# Altium schematic sheet FPGA_Bank_2_3_I2C.SchDoc
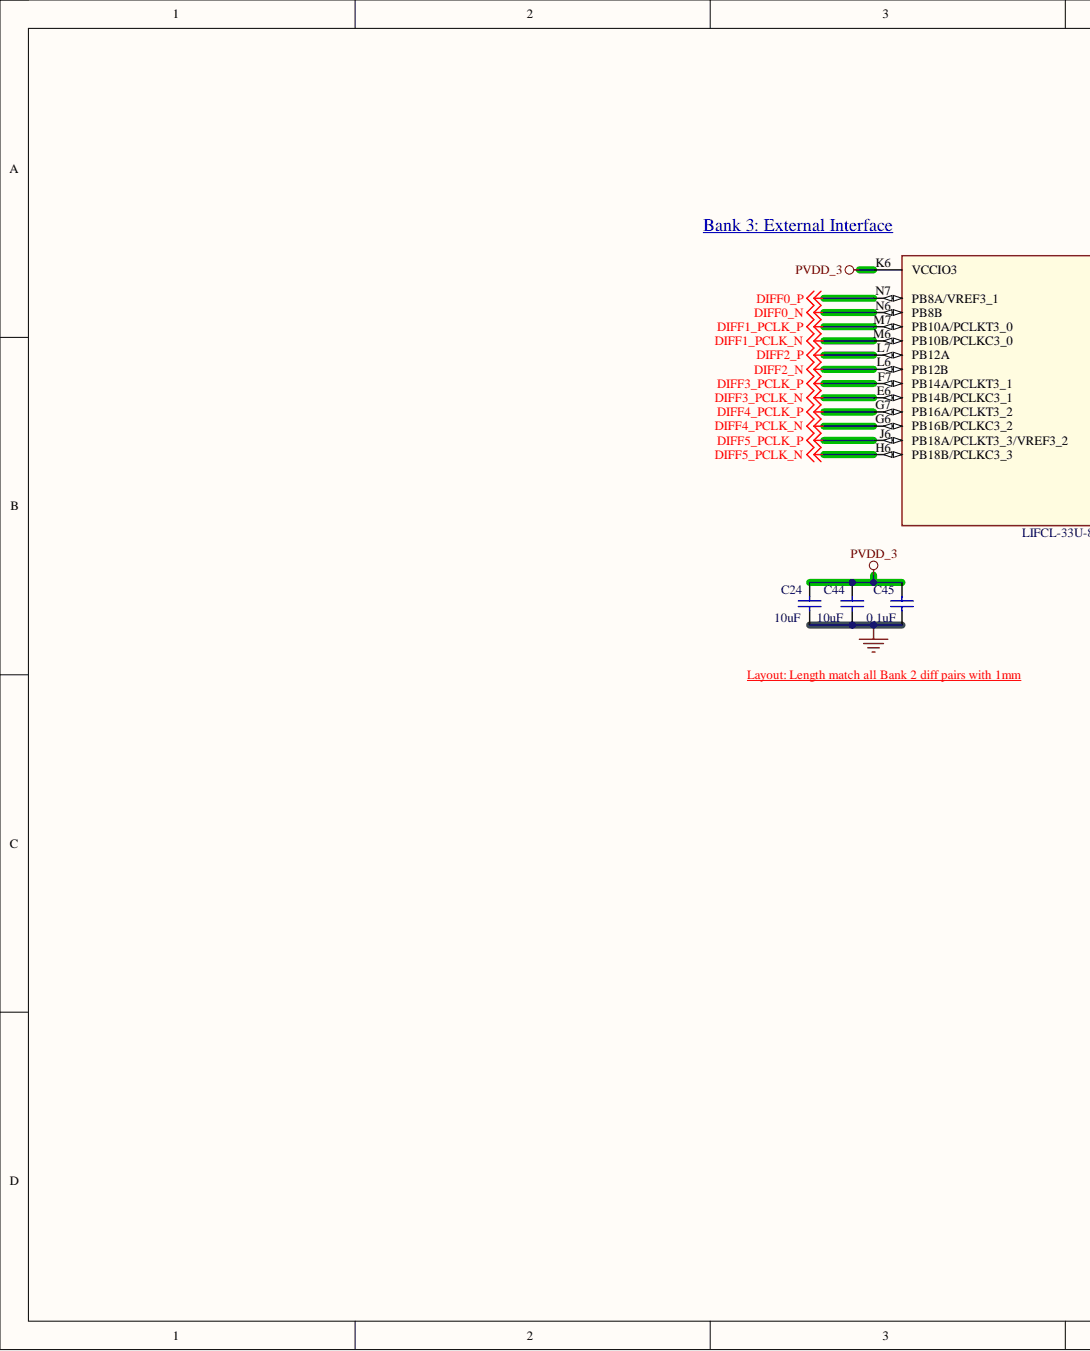
[diagram p0: FPGA_Bank_2_3_I2C.SchDoc, left]
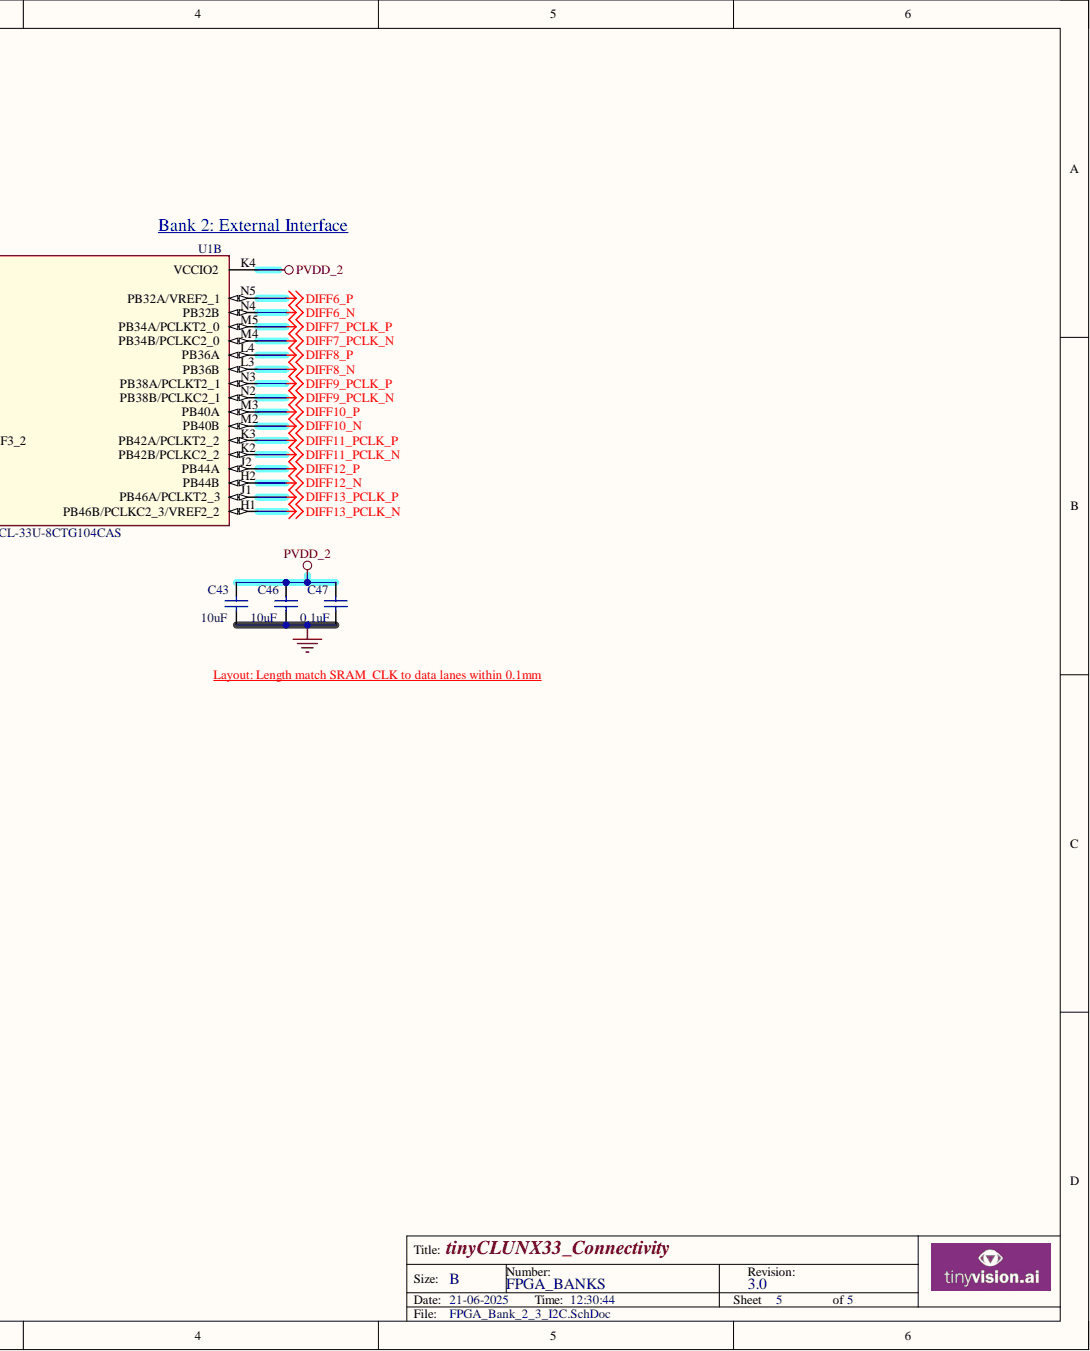
[diagram p1: FPGA_Bank_2_3_I2C.SchDoc, right]

## Parts
C24  10uF  [CAPC1005X55USR]
C43  10uF  [CAPC1005X55USR]
C44  10uF  [CAPC1005X55USR]
C45  0.1uF  [CAPC0603X33N]
C46  10uF  [CAPC1005X55USR]
C47  0.1uF  [CAPC0603X33N]
U1  LIFCL-33U-8CTG104CAS  [BGA104C65P8X13_550X850X100]  (part B)

## Nets
DIFF0_N: U1.N6(PB8B)
DIFF0_P: U1.N7(PB8A/VREF3_1)
DIFF1_PCLK_N: U1.M6(PB10B/PCLKC3_0)
DIFF1_PCLK_P: U1.M7(PB10A/PCLKT3_0)
DIFF2_N: U1.L6(PB12B)
DIFF2_P: U1.L7(PB12A)
DIFF3_PCLK_N: U1.E6(PB14B/PCLKC3_1)
DIFF3_PCLK_P: U1.F7(PB14A/PCLKT3_1)
DIFF4_PCLK_N: U1.G6(PB16B/PCLKC3_2)
DIFF4_PCLK_P: U1.G7(PB16A/PCLKT3_2)
DIFF5_PCLK_N: U1.H6(PB18B/PCLKC3_3)
DIFF5_PCLK_P: U1.J6(PB18A/PCLKT3_3/VREF3_2)
DIFF6_N: U1.N4(PB32B)
DIFF6_P: U1.N5(PB32A/VREF2_1)
DIFF7_PCLK_N: U1.M4(PB34B/PCLKC2_0)
DIFF7_PCLK_P: U1.M5(PB34A/PCLKT2_0)
DIFF8_N: U1.L3(PB36B)
DIFF8_P: U1.L4(PB36A)
DIFF9_PCLK_N: U1.N2(PB38B/PCLKC2_1)
DIFF9_PCLK_P: U1.N3(PB38A/PCLKT2_1)
DIFF10_N: U1.M2(PB40B)
DIFF10_P: U1.M3(PB40A)
DIFF11_PCLK_N: U1.K2(PB42B/PCLKC2_2)
DIFF11_PCLK_P: U1.K3(PB42A/PCLKT2_2)
DIFF12_N: U1.H2(PB44B)
DIFF12_P: U1.J2(PB44A)
DIFF13_PCLK_N: U1.H1(PB46B/PCLKC2_3/VREF2_2)
DIFF13_PCLK_P: U1.J1(PB46A/PCLKT2_3)
GND: C24.1 C43.1 C44.1 C45.1 C46.1 C47.1
PVDD_2: C43.2 C46.2 C47.2 U1.K4(VCCIO2)
PVDD_3: C24.2 C44.2 C45.2 U1.K6(VCCIO3)

## Library data of the parts
C24 C43 C44 C46 = Cap_10uF_X5R_20%_10V_0402: CAP CER 10UF 10V X5R 0402; Manufacturer: Samsung Electro-Mechanics; Manufacturer Part Number: CL05A106MP5NUNC; Part #: E-CAP-042; Component Kind: Standard; ComponentLink1Description: New link; Height: 0.55mm; Package: 0402; Supplier 1: Digi-Key; Supplier Part Number 1: 1276-1450-1-ND; value: 10uF; Library Name: tinyCLUNX33_Compute.SCHLIB
C45 C47 = CAP CER 0.1UF 10V X7S 0201: CAP CER 0.1UF 10V X5R 0201; Manufacturer: Murata Electronics; Manufacturer Part Number: GRM033R61A104KE15D; Component Kind: Standard; Height: 0.33mm; Library Name: Smart Camera v2.SCHLIB; Package: 0201; Supplier 1: Digi-Key; Supplier Part Number 1: 490-5881-1-ND; value: 0.1uF
U1 = LIFCL-33U-8CTG104CAS: Manufacturer: LATTICE; Component Kind: Standard; Library Name: NX Module.SCHLIB; Manufacturer Part Number: LIFCL-33U-8CTG104CAS
